# Altium schematic sheet Nucleo-64.SchDoc
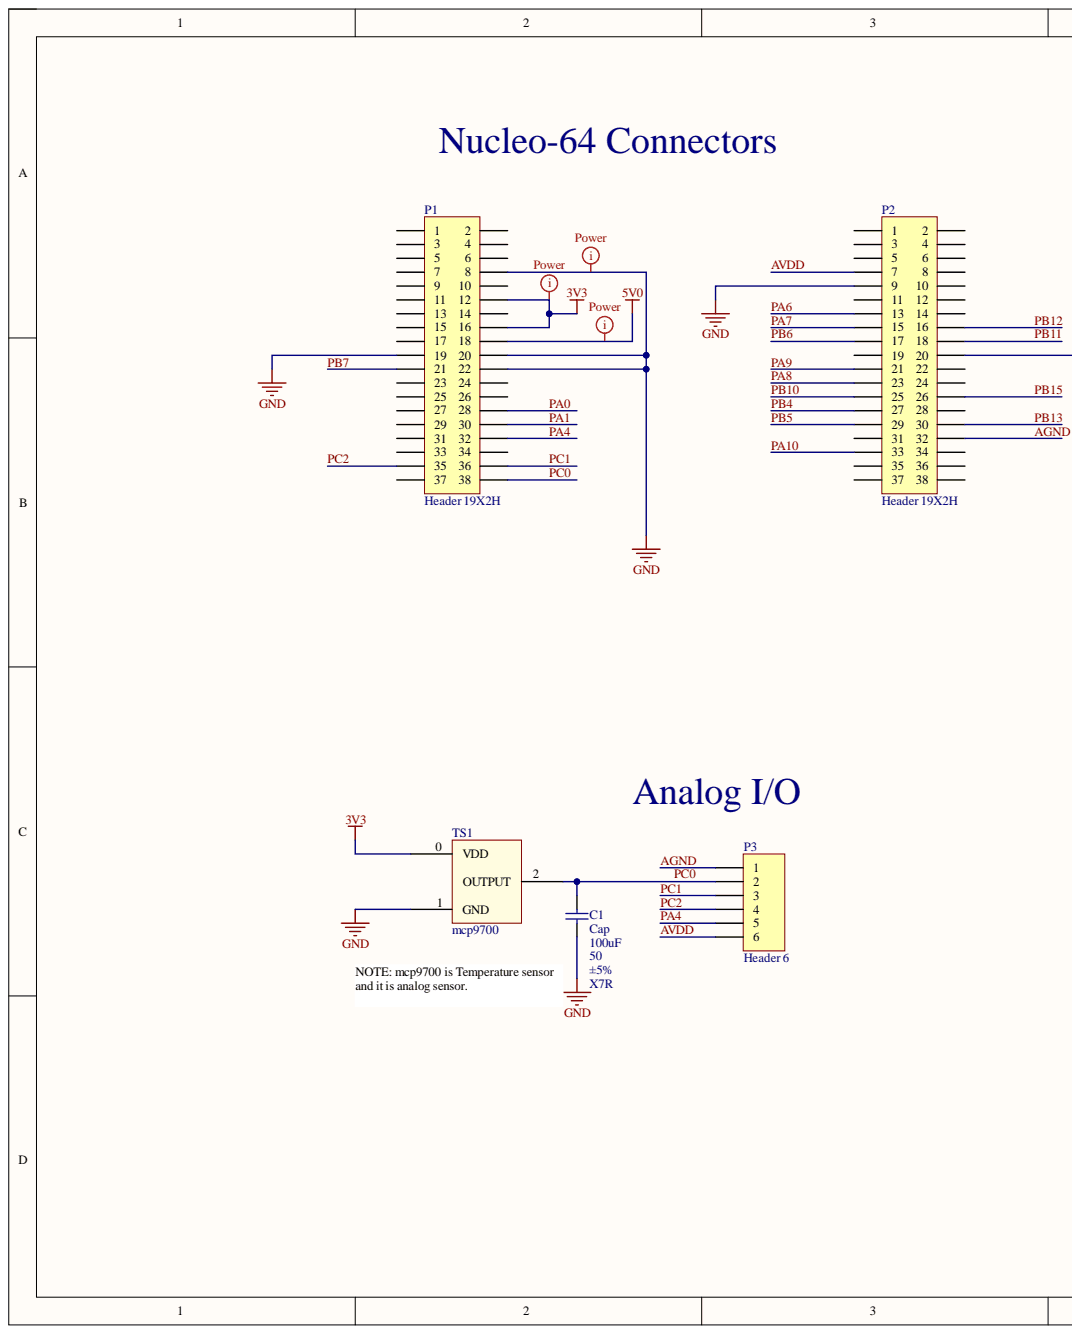
[diagram p0: Nucleo-64.SchDoc, left]
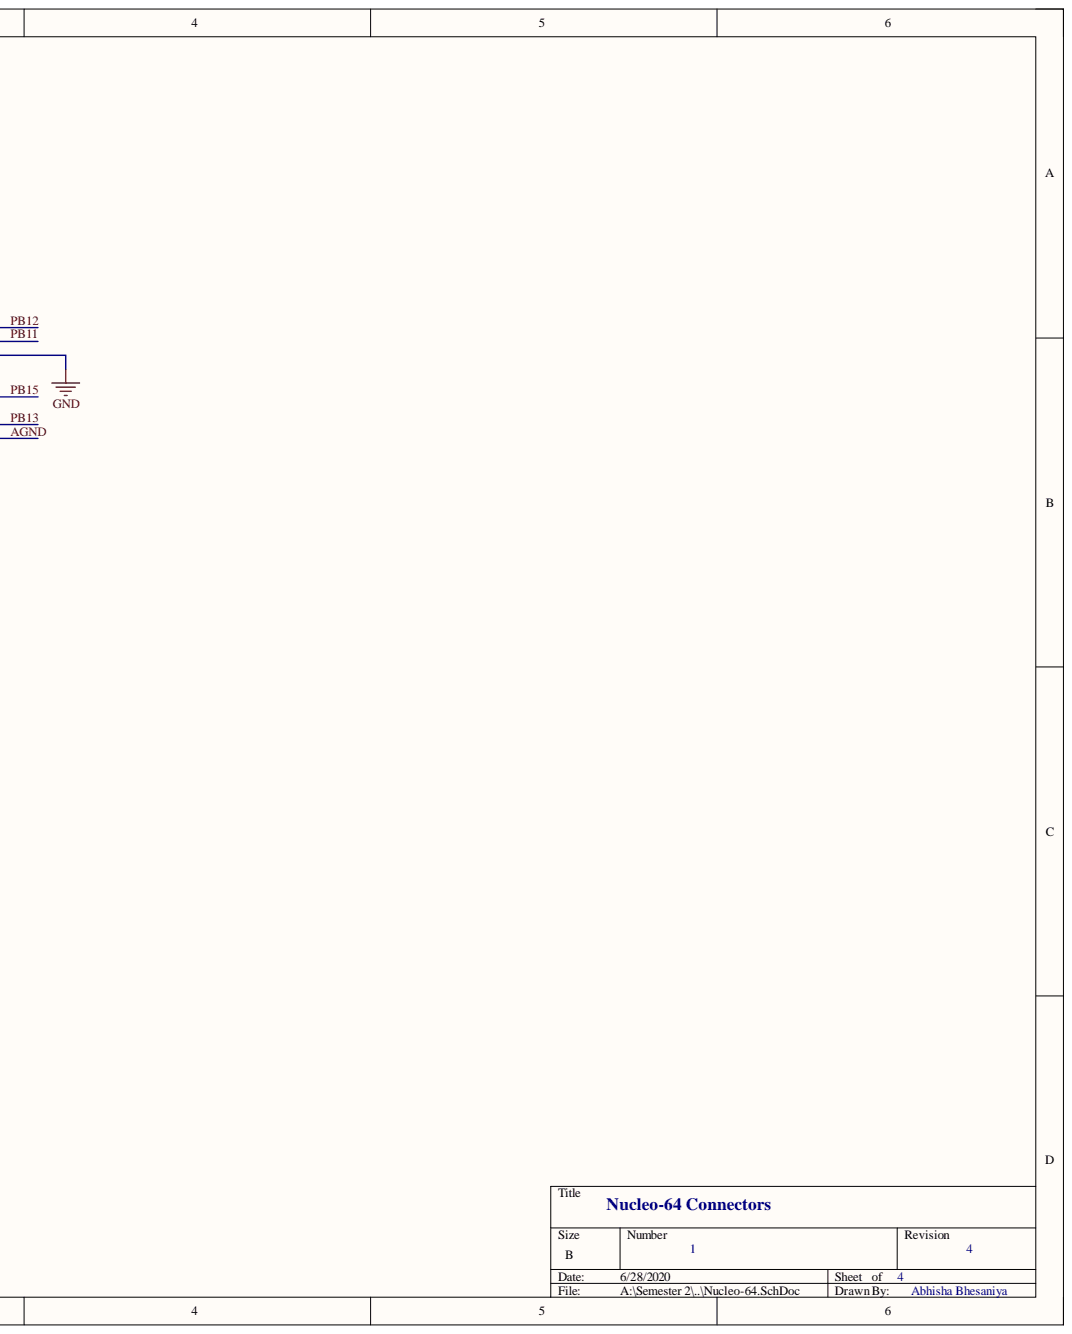
[diagram p1: Nucleo-64.SchDoc, right]

## Parts
C1  Cap  value 100uF  Tolerance: ±5%  [CAPC2012X09M]
P1  Header 19X2H  [PPPC192LFBN-RC]
P2  Header 19X2H  [PPPC192LFBN-RC]
P3  Header 6  [HDR1X6]
TS1  mcp9700

## Nets
3V3: P1.12 P1.16 TS1.0
5V0: P1.18
GND: C1.1 P1.8 P1.19 P1.20 P1.22 P2.9 P2.20 TS1.1
AGND: P2.32 P3.1
AVDD: P2.7 P3.6
PA0: P1.28
PA1: P1.30
PA4: P1.32 P3.5
PA6: P2.13
PA7: P2.15
PA8: P2.23
PA9: P2.21
PA10: P2.33
PB4: P2.27
PB5: P2.29
PB6: P2.17
PB7: P1.21
PB10: P2.25
PB11: P2.18
PB12: P2.16
PB13: P2.30
PB15: P2.26
PC0: C1.2 P1.38 P3.2 TS1.2
PC1: P1.36 P3.3
PC2: P1.35 P3.4

Not connected: P1 1 2 3 4 5 6 7 9 10 11 13 14 15 17 23 24 25 26 27 29 31 33 34 37; P2 1 2 3 4 5 6 8 10 11 12 14 19 22 24 28 31 34 35 36 37 38

## Library data of the parts
C1 = CAP-X7R-.1uF-50V-±5%: CAP CER .1uF 50v X7R 0805; MPN: C0805C104J5RACTU; PN: 399-1171-1-ND; WV: 50; Component Kind: Standard; Library Name: esd_library.IntLib; Name: CAP-X7R-.1uF-50V-±5%; Type: X7R; URL: http://www.digikey.ca/product-detail/en/C0805C104J5RACTU/399-1171-1-ND
P1 P2 = Header 19X2H: 38 Position Header Connector 0.100in (2.54mm) Through Hole Gold; PN: S7122-ND; Component Kind: Standard; Library Name: esd_library.IntLib; MPN: PPPC192LFBN-RC; Signal Integrity: Connector; URL: https://www.digikey.ca/product-detail/en/sullins-connector-solutions/PPPC192LFBN-RC/S7122-ND
P3 = Header 6: Header, 6-Pin Male; MPN: PEC06SFAN; PN: S1212E-06-ND; Component Kind: Standard; Library Name: esd_library.IntLib; URL: http://www.digikey.ca/product-detail/en/PEC06SFAN/S1212E-06-ND
TS1 = mcp9700: Component Kind: Standard; Library Name: ourlibrary.SchLib
